# Altium schematic sheet TPVSI_DC_Voltage_Sensing.SchDoc(TPVSI_DC_Voltage_Sensing)
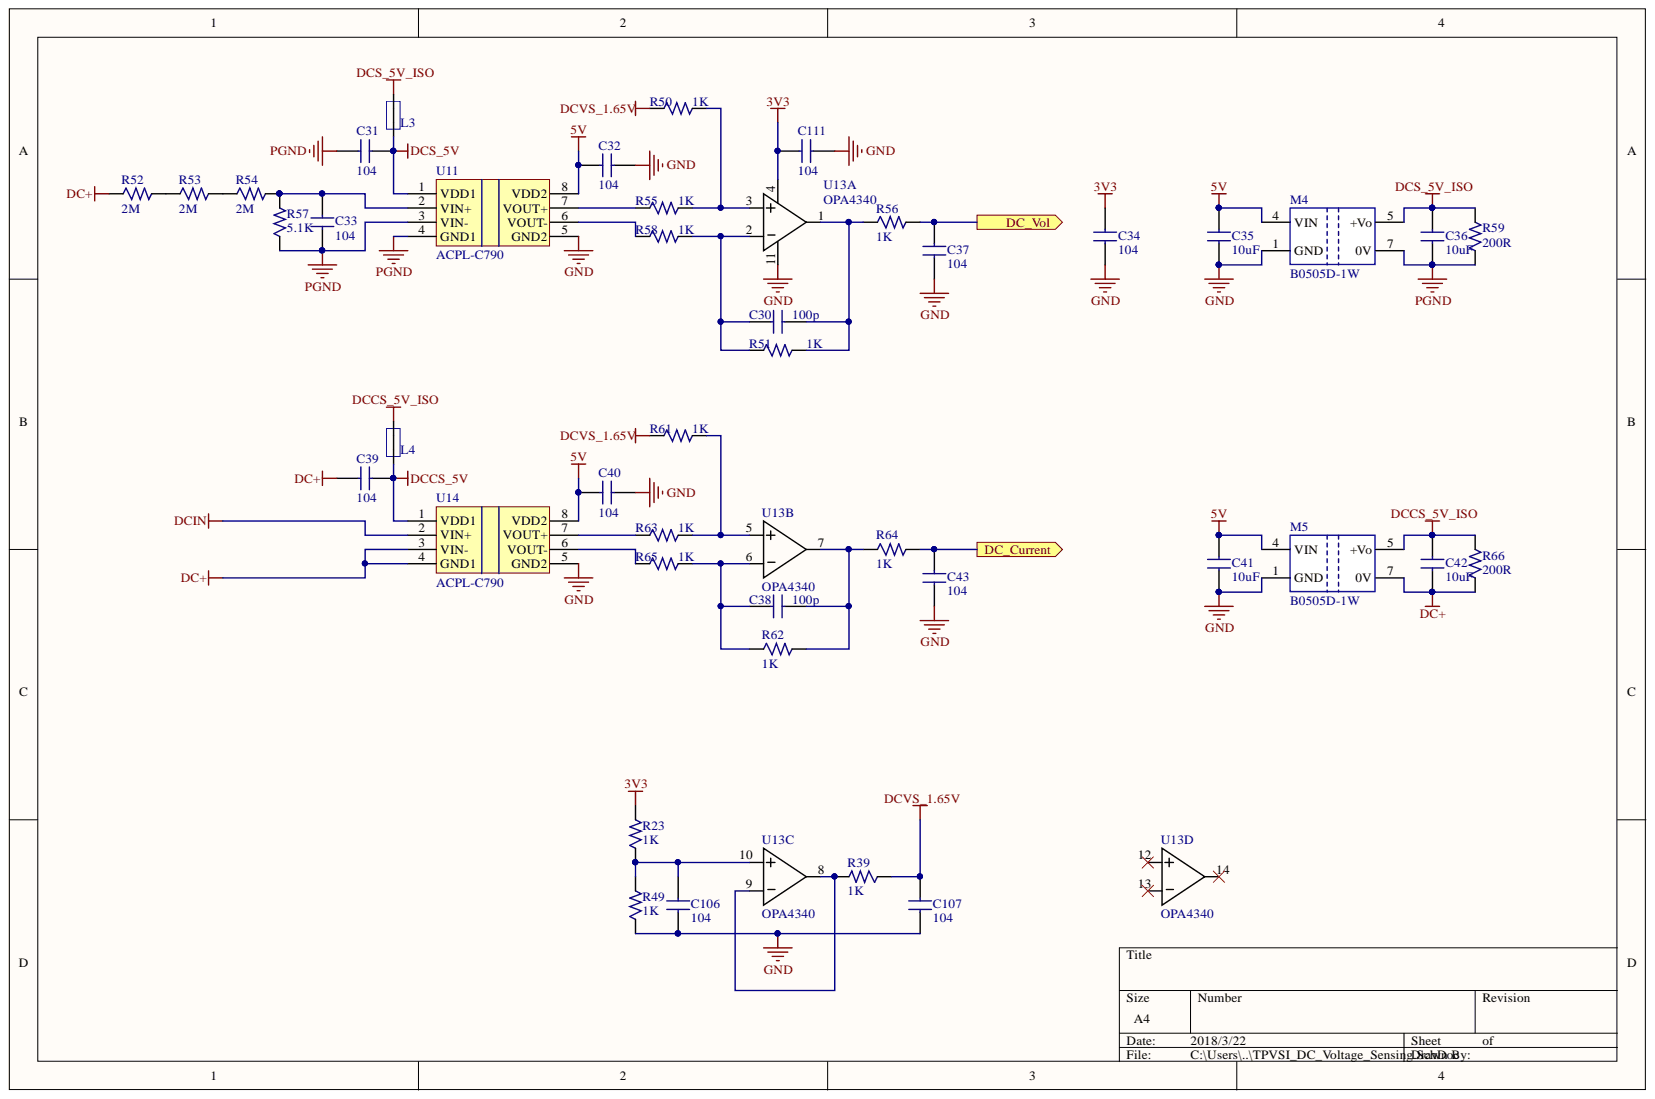
[diagram p0: TPVSI_DC_Voltage_Sensing.SchDoc]

## Parts
C30  100p  [C-RAD-0603]
C31  104  [C-RAD-0603]
C32  104  [C-RAD-0603]
C33  104  [C-RAD-0603]
C34  104  [C-RAD-0603]
C35  10uF  [1210]
C36  10uF  [1210]
C37  104  [C-RAD-0603]
C38  100p  [C-RAD-0603]
C39  104  [C-RAD-0603]
C40  104  [C-RAD-0603]
C41  10uF  [1210]
C42  10uF  [1210]
C43  104  [C-RAD-0603]
C106  104  [C-RAD-0603]
C107  104  [C-RAD-0603]
C111  104  [C-RAD-0603]
L3  Inductor_Small  [1206]  (value not drawn)
L4  Inductor_Small  [1206]  (value not drawn)
M4  B0505D-1W  [B0505D-1W]
M5  B0505D-1W  [B0505D-1W]
R23  1K  [0603_1]
R39  1K  [0603_1]
R49  1K  [0603_1]
R50  1K  [0603_1]
R51  1K  [0603_1]
R52  2M  [2512]
R53  2M  [2512]
R54  2M  [2512]
R55  1K  [0603_1]
R56  1K  [0603_1]
R57  5.1K  [0603_1]
R58  1K  [0603_1]
R59  200R  [1206]
R61  1K  [0603_1]
R62  1K  [0603_1]
R63  1K  [0603_1]
R64  1K  [0603_1]
R65  1K  [0603_1]
R66  200R  [1206]
U11  ACPL-C790  [SSO8]
U13  OPA4340  [SOP-14N]  (parts A,B,C,D)
U14  ACPL-C790  [SSO8]

## Ports
DC_Current, DC_Vol

## Nets
3V3: C34.2 C111.1 R23.2 U13.4
5V: C32.1 C35.2 C40.1 C41.2 M4.4(VIN) M5.4(VIN) U11.8(VDD2) U14.8(VDD2)
DC+: C39.1 C42.1 M5.7(0V) R52.1 R66.1 U14.3(VIN-) U14.4(GND1)
DCCS_5V: C39.2 L4.1 U14.1(VDD1)
DCCS_5V_ISO: C42.2 L4.2 M5.5(+Vo) R66.2
DCIN: U14.2(VIN+)
DCS_5V: C31.2 L3.1 U11.1(VDD1)
DCS_5V_ISO: C36.2 L3.2 M4.5(+Vo) R59.2
DCVS_1.65V: C107.2 R39.1 R50.1 R61.1
GND: C32.2 C34.1 C35.1 C37.1 C40.2 C41.1 C43.1 C106.1 C107.1 C111.2 M4.1(GND) M5.1(GND) R49.1 U11.5(GND2) U13.11 U14.5(GND2)
PGND: C31.1 C33.1 C36.1 M4.7(0V) R57.1 R59.1 U11.3(VIN-) U11.4(GND1)
DC_Current: C43.2 R64.2 ; port DC_Current
DC_Vol: C37.2 R56.2 ; port DC_Vol
NetC30_1: C30.1 R51.1 R58.2 U13.2
NetC30_2: C30.2 R51.2 R56.1 U13.1
NetC33_2: C33.2 R54.2 R57.2 U11.2(VIN+)
NetC38_1: C38.1 R62.1 R65.2 U13.6
NetC38_2: C38.2 R62.2 R64.1 U13.7
NetC106_2: C106.2 R23.1 R49.2 U13.10
NetR39_2: R39.2 U13.8 U13.9
NetR50_2: R50.2 R55.2 U13.3
NetR52_2: R52.2 R53.1
NetR53_2: R53.2 R54.1
NetR55_1: R55.1 U11.7(VOUT+)
NetR58_1: R58.1 U11.6(VOUT-)
NetR61_2: R61.2 R63.2 U13.5
NetR63_1: R63.1 U14.7(VOUT+)
NetR65_1: R65.1 U14.6(VOUT-)

Not connected: U13 12 13 14

## Library data of the parts
C30-C43 C106 C107 C111 = cap: Component Kind: Standard; Library Name: Chu_16.IntLib
R23 R39 R49-R59 R61-R66 = Res: Component Kind: Standard; Library Name: Chu_16.IntLib
L3 L4 = Inductor_Small: Component Kind: Standard; Library Name: Chu_16.IntLib
M4 M5 = B0505D-1W: Component Kind: Standard; Library Name: Chu_16.IntLib
U11 U14 = ACPL-C790: Component Kind: Standard; Library Name: Chu_16.IntLib
U13 = OPA4340: Component Kind: Standard; Library Name: Chu_16.IntLib
